# Altium schematic sheet sensors.SchDoc
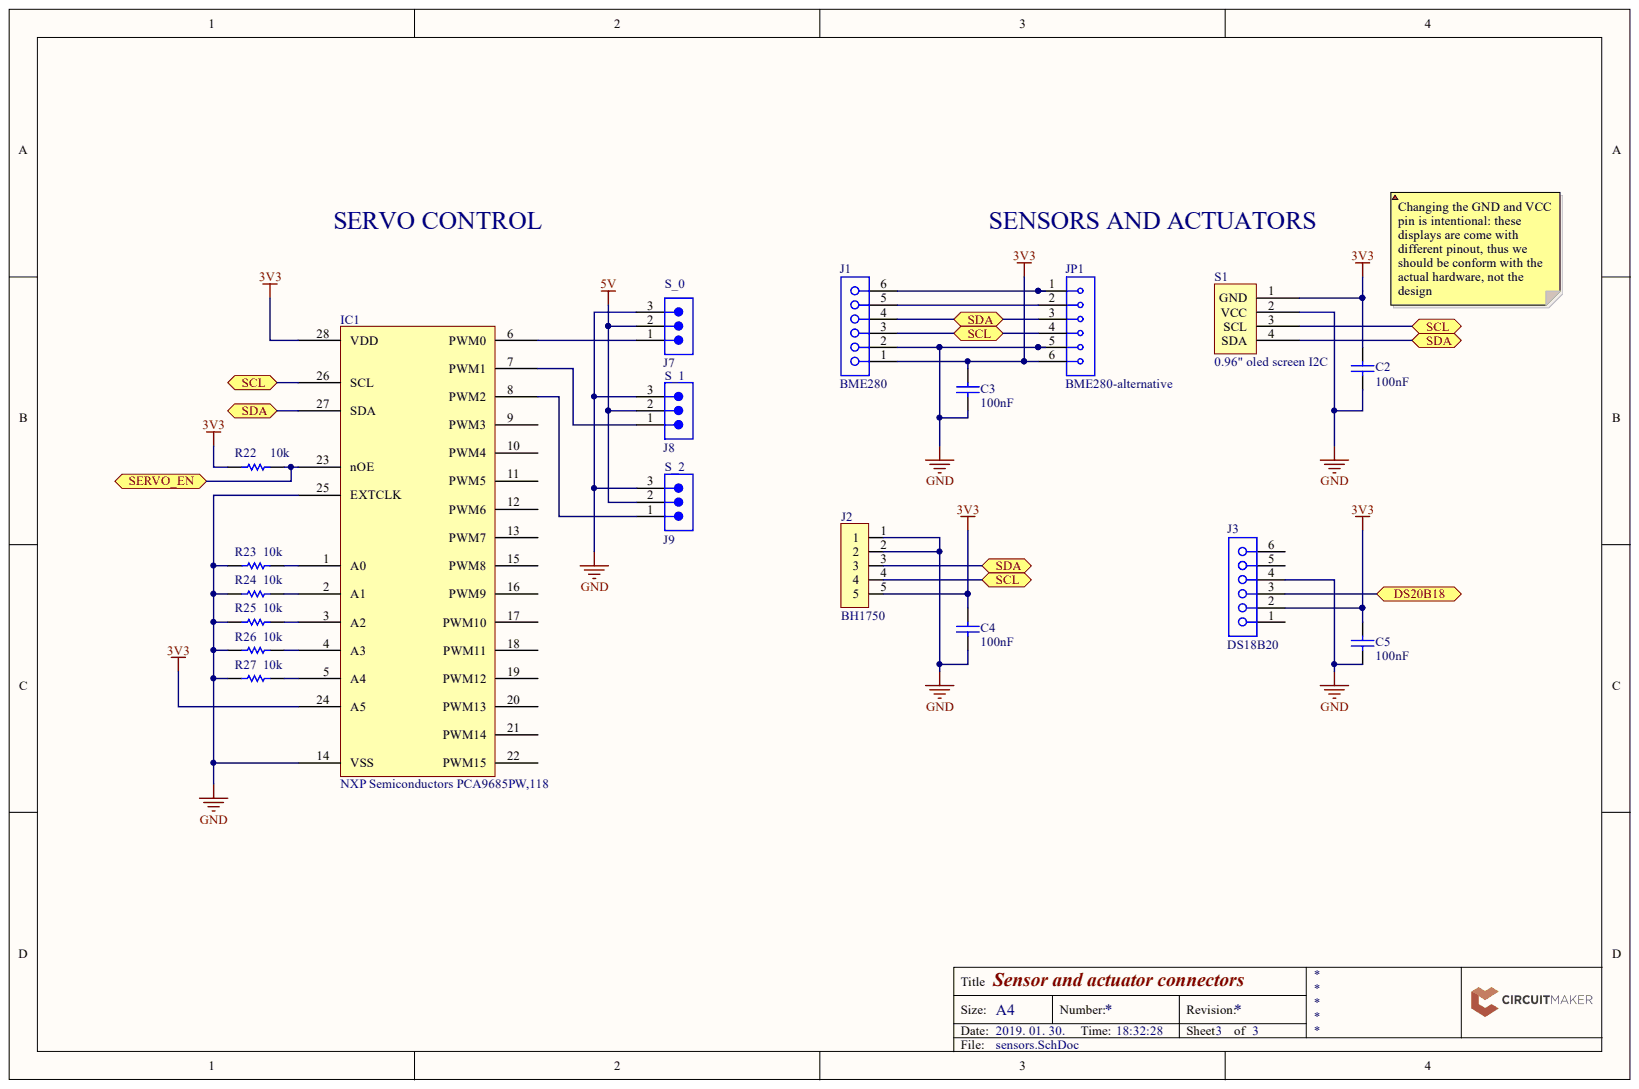
[diagram p0: sensors.SchDoc]

## Parts
C2  100nF  [PCB-121845-1]
C3  100nF  [PCB-121845-1]
C4  100nF  [PCB-121845-1]
C5  100nF  [PCB-121845-1]
IC1  NXP Semiconductors PCA9685PW,118  Manufacturer Part Number: PCA9685PW,118  [SOP28]
J1  BME280  [SMTC-SSW-106-02-X-S-RA]
J2  BH1750  [PCB-rnlen50cc5tt6vc22c1r-1]
J3  DS18B20  [PCB-62c808dr5bbz8f3661kq-1]
J7  S_0  [PCB-qlw8evzkdr08cthfrp7e-1]
J8  S_1  [PCB-qlw8evzkdr08cthfrp7e-1]
J9  S_2  [PCB-qlw8evzkdr08cthfrp7e-1]
JP1  BME280-alternative  [PCB-di8nzhz8ya7afv8i1myi-1]
R22  10k  [ERJ-8ENF1001V]
R23  10k  [ERJ-8ENF1001V]
R24  10k  [ERJ-8ENF1001V]
R25  10k  [ERJ-8ENF1001V]
R26  10k  [ERJ-8ENF1001V]
R27  10k  [ERJ-8ENF1001V]
S1  0.96" oled screen I2C  [PCB-gpl9abthx898mkx7s1do-1]

## Ports
DS20B18, SCL, SDA, SERVO_EN

## Nets
3V3: C2.2 C3.2 C4.2 C5.2 IC1.24(A5) IC1.28(VDD) J1.1 J2.5 J3.2 JP1.6 R22.1 S1.1(GND)
5V: J7.2 J8.2 J9.2
GND: C2.1 C3.1 C4.1 C5.1 IC1.14(VSS) IC1.25(EXTCLK) J1.2 J2.1 J2.2 J3.4 J7.3 J8.3 J9.3 JP1.5 R23.1 R24.1 R25.1 R26.1 R27.1 S1.2(VCC)
DS20B18: J3.3 ; port DS20B18
SCL: IC1.26(SCL) J1.3 J2.4 JP1.4 S1.3(SCL) ; port SCL
SDA: IC1.27(SDA) J1.4 J2.3 JP1.3 S1.4(SDA) ; port SDA
SERVO_EN: IC1.23(nOE) R22.2 ; port SERVO_EN
NetIC1_1: IC1.1(A0) R23.2
NetIC1_2: IC1.2(A1) R24.2
NetIC1_3: IC1.3(A2) R25.2
NetIC1_4: IC1.4(A3) R26.2
NetIC1_5: IC1.5(A4) R27.2
NetIC1_6: IC1.6(PWM0) J7.1
NetIC1_7: IC1.7(PWM1) J8.1
NetIC1_8: IC1.8(PWM2) J9.1
NetJ1_5: J1.5 JP1.2
NetJ1_6: J1.6 JP1.1

Not connected: IC1 9(PWM3) 10(PWM4) 11(PWM5) 12(PWM6) 13(PWM7) 15(PWM8) 16(PWM9) 17(PWM10) 18(PWM11) 19(PWM12) 20(PWM13) 21(PWM14) 22(PWM15); J3 1 5 6

## Library data of the parts
C2-C5 = CMP-121845-2: CAP CER 0.1UF 25V 5% NP0 1206; Manufacturer Part Number: GRM31C5C1E104JA01L; Capacitance: 1e-07 F; Case/Package (SI): 3216; Dielectric Characteristic: C0G/NP0; Dielectric Material: Ceramic Multilayer; Insulation Resistance: 10000000000.0 Ω; Lead-Free Status: Lead Free; Voltage Rating (DC): 25.0 V; Capacitance Tolerance: ±5%; Component Kind: Standard; Library Name: CommunityVault; Lifecycle Status: Active; Mounting Style: Surface Mount; Number of Pins: 2; OctopartID: 7fd4b6dca5cda013; Octopart Url: https://octopart.com/grm31c5c1e104ja01l-murata-196479; REACH SVHC Compliance: No SVHC; RoHS: Compliant; Size-Height: 0.0016 m; Size-Length: 0.0032 m; Size-Thickness: 0.0016 m; Size-Width: 0.0016 m
IC1 = CMP-d7fd1e432ce0083a-4: PCA9685 Series 5.5V 25 mA 1 MHz 12-bit PWM Fm+I2C-Bus LED Controller - TSSOP-28; Component Kind: Standard; Library Name: CommunityVault; Mounting Style: Surface Mount; Packaging: Tape & Reel (TR); RoHS: Compliant
J1 = CMP-3887538-2: SQ Post Socket, Through-hole, Right Angle, -55 to 105 degC, 2.54mm Pitch, 6-Pin, Female, RoHS; Manufacturer Part Number: SSW-106-02-T-S-RA; Number of Contacts: 6; Number of Mating Cycles: 100; Pin Pitch: 0.00254 m; Color: Black; Component Kind: Standard; Contact Material: Phosphor Bronze; Contact Plating: Tin; Gender: Female; Library Name: CommunityVault; Mounting Style: Through Hole; Number of Rows: 1; OctopartID: fa6233e4336925a5; Octopart Url: https://octopart.com/ssw-106-02-t-s-ra-samtec-9235565; REACH SVHC Compliance: No SVHC; RoHS: Yes
J2 = CMP-b5d67e8662e76a11-19: MTA-100 5 Position Through-Hole Single Row Straight Wire to Board Header; Manufacturer Part Number: 3-644456-5; ELV Compliant: Compliant; Gender: Male; Lead-Free Status: Lead Free; Mounting Angle: 180 °; Number of Contacts: 5; Pin Pitch: 2.54 mm; Shrouded: No; Voltage Rating (AC): 250 V; Component Kind: Standard; Contact Material: Copper Alloy; Contact Plating: Tin; Flammability Rating: UL 94 V-0; Housing Color: Natural; Housing Material: Polyester; Library Name: CommunityVault; Lifecycle Status: Active; Mounting Style: Through Hole; Number of Positions: 5; Number of Rows: 1; OctopartID: b5d67e8662e76a11; Octopart URL: http://octopart.com/3-644456-5-te+connectivity+%2F+amp-39514711; Orientation: Vertical; Packaging: Box, Bag; RoHS: Compliant; Size-Height: 2.54 mm; Size-Length: 12.4 mm; Size-Width: 2.34 mm
J3 = CMP-6430ad56bfa2bbf7-6: Conn RJ-12 F 6 POS 2.54mm Solder RA Thru-Hole 6 Terminal 1 Port Cat 3 Tray; Component Kind: Standard; Library Name: CommunityVault
J7-J9 = CMP-1d18d4412a33c2df-10: HARWIN M20 Series, 2.54mm Pitch 3 Way 1 Row Straight PCB Header, Solder Termination, 3A; Component Kind: Standard; Library Name: CommunityVault
JP1 = CMP-ea272149382c35e0-4: Conn; Rect; UnshroudedHdr; 6Cnts; ThruHole; BergStik; 2.54mmPin-Spng; Vert; 1-Row; Component Kind: Standard; Library Name: CommunityVault
R22-R27 = CMP-3663831-4: Res Thick Film 3.2 x 1.6 mm 1K Ohm 1% 0.25W(1/4W) 100ppm/ C Molded SMD Punched Carrier T/R; Power Rating: 250 mW; Resistance: 1.00 kΩ; Component Kind: Standard; Library Name: CommunityVault; Lifecycle Status: Not Listed by Manufacturer; Manufacturer Part Number: ERJ-8ENF1001V; Mounting Style: Surface Mount; Number of Pins: 2; OctopartID: 229be8dcb721fd5c; Octopart URL: http://octopart.com/erj-8enf1001v-panasonic-55423196; Packaging: Cut Tape (CT), Tape & Reel (TR); Resistance Tolerance: ±1%; RoHS: Compliant; Size-Height: 600 µm; Size-Length: 2.00 mm; Size-Width: 1.60 mm
S1 = CMP-16c6de3b0b9bc901-12: Strain Relief; Nylon; Straight-through; Black; 13/32 in.; Color: Black; Component Kind: Standard; Library Name: CommunityVault; Mounting Style: Through Hole; Octopart URL: https://octopart.com/938-adafruit+industries-32979003; RoHS: Compliant
